# Altium schematic sheet 04_VGA.SchDoc(04_VGA)
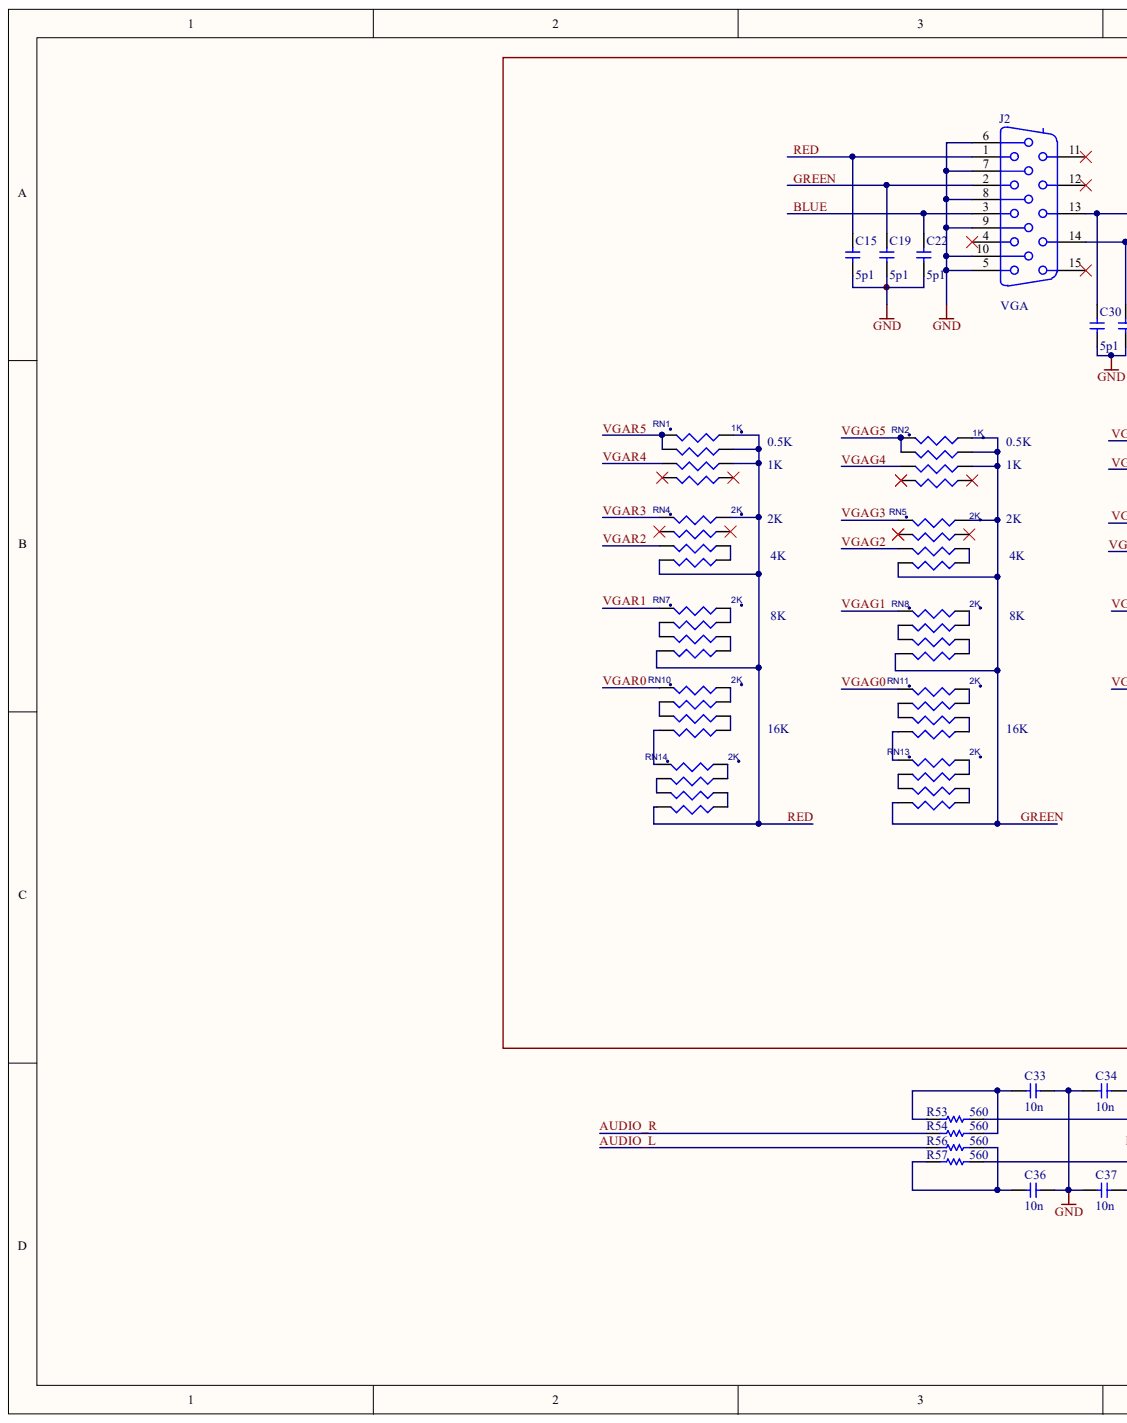
[diagram p0: 04_VGA.SchDoc, left]
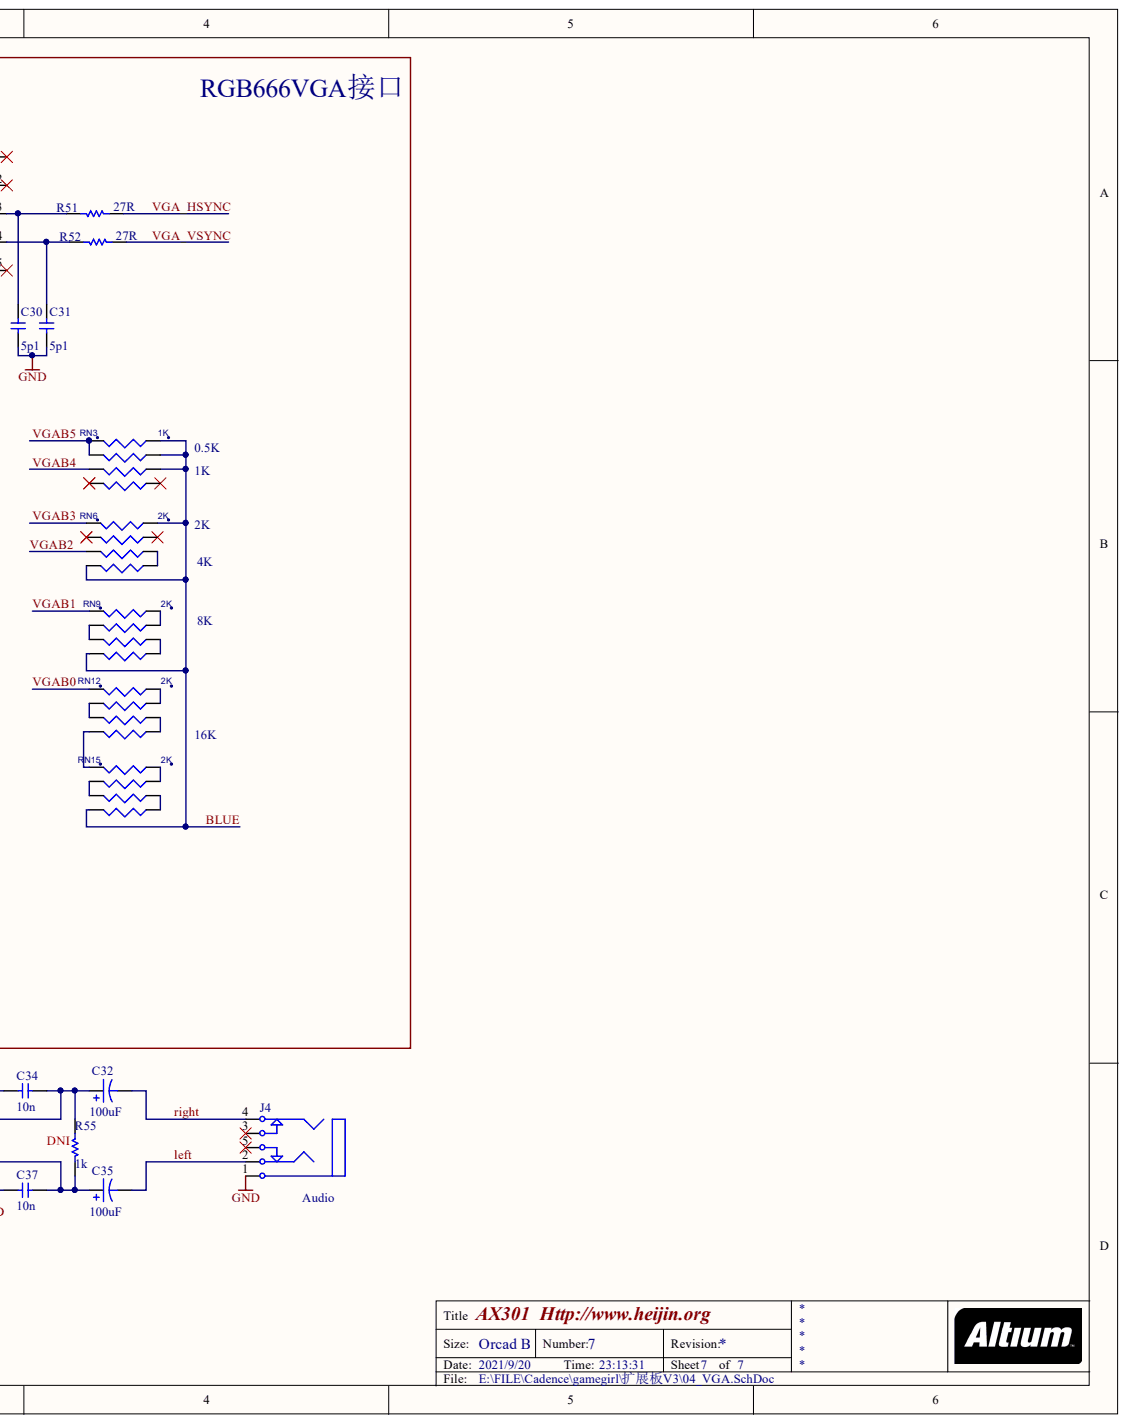
[diagram p1: 04_VGA.SchDoc, right]

## Parts
C15  value 5p1  [0603]  (hidden comment: 0603)
C19  value 5p1  [0603]  (hidden comment: 0603)
C22  value 5p1  [0603]  (hidden comment: 0603)
C30  value 5p1  [0603]  (hidden comment: 0603)
C31  value 5p1  [0603]  (hidden comment: 0603)
C32  value 100uF  [CAPT]  (hidden comment: T520D)
C33  value 10n  [0603]  (hidden comment: 0603)
C34  value 10n  [0603]  (hidden comment: 0603)
C35  value 100uF  [CAPT]  (hidden comment: T520D)
C36  value 10n  [0603]  (hidden comment: 0603)
C37  value 10n  [0603]  (hidden comment: 0603)
J2  VGA  [VGA]
J4  Audio  [phonejack]
R51  value 27R  [0603]  (hidden comment: 0603)
R52  value 27R  [0603]  (hidden comment: 0603)
R53  value 560  [0603]  (hidden comment: 0805)
R54  value 560  [0603]  (hidden comment: 0805)
R55  value 1k  [0603]  (hidden comment: 0603)
R56  value 560  [0603]  (hidden comment: 0805)
R57  value 560  [0603]  (hidden comment: 0805)
RN1  1K  [RN-8P]
RN2  1K  [RN-8P]
RN3  1K  [RN-8P]
RN4  2K  [RN-8P]
RN5  2K  [RN-8P]
RN6  2K  [RN-8P]
RN7  2K  [RN-8P]
RN8  2K  [RN-8P]
RN9  2K  [RN-8P]
RN10  2K  [RN-8P]
RN11  2K  [RN-8P]
RN12  2K  [RN-8P]
RN13  2K  [RN-8P]
RN14  2K  [RN-8P]
RN15  2K  [RN-8P]

## Nets
GND: C15.2 C19.2 C22.2 C30.2 C31.2 C33.1 C34.2 C36.1 C37.2 J2.5 J2.6 J2.7 J2.8 J2.9 J2.10 J4.1
AUDIO_L: R56.1
AUDIO_R: R54.1
BLUE: C22.1 J2.3 RN3.2 RN3.3 RN3.4 RN6.4 RN6.8 RN9.8 RN15.8
GREEN: C19.1 J2.2 RN2.2 RN2.3 RN2.4 RN5.4 RN5.8 RN8.8 RN13.8
LEFT: C35.2 J4.2
RED: C15.1 J2.1 RN1.2 RN1.3 RN1.4 RN4.4 RN4.8 RN7.8 RN14.8
RIGHT: C32.2 J4.4
VGA_HSYNC: R51.1
VGA_VSYNC: R52.1
VGAB0: RN12.5
VGAB1: RN9.5
VGAB2: RN6.7
VGAB3: RN6.5
VGAB4: RN3.7
VGAB5: RN3.5 RN3.6
VGAG0: RN11.5
VGAG1: RN8.5
VGAG2: RN5.7
VGAG3: RN5.5
VGAG4: RN2.7
VGAG5: RN2.5 RN2.6
VGAR0: RN10.5
VGAR1: RN7.5
VGAR2: RN4.7
VGAR3: RN4.5
VGAR4: RN1.7
VGAR5: RN1.5 RN1.6
NetC30_1: C30.1 J2.13 R51.2
NetC31_1: C31.1 J2.14 R52.2
NetC32_1: C32.1 C34.1 R53.2 R55.1
NetC33_2: C33.2 R53.1 R54.2
NetC35_1: C35.1 C37.1 R55.2 R57.2
NetC36_2: C36.2 R56.2 R57.1
NetRN4_1: RN4.1 RN4.2
NetRN5_1: RN5.1 RN5.2
NetRN6_1: RN6.1 RN6.2
NetRN7_1: RN7.1 RN7.2
NetRN7_3: RN7.3 RN7.4
NetRN7_6: RN7.6 RN7.7
NetRN8_1: RN8.1 RN8.2
NetRN8_3: RN8.3 RN8.4
NetRN8_6: RN8.6 RN8.7
NetRN9_1: RN9.1 RN9.2
NetRN9_3: RN9.3 RN9.4
NetRN9_6: RN9.6 RN9.7
NetRN10_1: RN10.1 RN10.2
NetRN10_3: RN10.3 RN10.4
NetRN10_6: RN10.6 RN10.7
NetRN10_8: RN10.8 RN14.5
NetRN11_1: RN11.1 RN11.2
NetRN11_3: RN11.3 RN11.4
NetRN11_6: RN11.6 RN11.7
NetRN11_8: RN11.8 RN13.5
NetRN12_1: RN12.1 RN12.2
NetRN12_3: RN12.3 RN12.4
NetRN12_6: RN12.6 RN12.7
NetRN12_8: RN12.8 RN15.5
NetRN13_1: RN13.1 RN13.2
NetRN13_3: RN13.3 RN13.4
NetRN13_6: RN13.6 RN13.7
NetRN14_1: RN14.1 RN14.2
NetRN14_3: RN14.3 RN14.4
NetRN14_6: RN14.6 RN14.7
NetRN15_1: RN15.1 RN15.2
NetRN15_3: RN15.3 RN15.4
NetRN15_6: RN15.6 RN15.7

Not connected: J2 4 11 12 15; J4 3 5; RN1 1 8; RN2 1 8; RN3 1 8; RN4 3 6; RN5 3 6; RN6 3 6

## Library data of the parts
C15 C19 C22 C30 C31 = CAP_0805: 0805 footprint non-polarised capacitor; Component Kind: Standard; Library Name: Integrated Comp_lib.IntLib
C32 C35 = T520D: Polymer Tantalum Chip Capacitor, T520 Series - KO-CAP Polymer; Code_JEITA: 7343-31; Component Kind: Standard; ComponentLink1Description: Manufacturer Link; ComponentLink1URL: http://www.kemet.com/; ComponentLink2Description: Datasheet; ComponentLink2URL: http://www.kemet.com/kemet/web/homepage/kechome.nsf/vapubfilesname/F3102TA.pdf/$file/F3102TA.pdf; DatasheetDocument: Mar-2001; LatestRevisionDate: 19-Mar-2004; LatestRevisionNote: Silkscreen dimensions re-sized.; Library Name: lib.PcbLib; PackageDocument: Mar-2001; PackageReference: D; Published: 17-Jul-2002; Signal Integrity: T520D; Simulation: CAP; Publisher: Altium Limited
C33 C34 C36 C37 = CAP_0603: 0603 footprint non-polorised capacitor; Component Kind: Standard; Library Name: Integrated Comp_lib.IntLib
J2 = Connector 15: Receptacle Assembly, 15-Pin, Sim Line Connector; Gender: Receptacle Header; PackageDocument: B; Positions: 15; Component Kind: Standard; DatasheetDocument: 24-Nov-1999; LatestRevisionDate: 17-Jul-2002; LatestRevisionNote: Re-released for DXP Platform.; Library Name: Miscellaneous Connectors.IntLib; Orientation: Right Angle; PackageReference: 788574; Published: 15-Jun-2001; Publisher: Altium Limited
J4 = phonejack: Component Kind: Standard; Library Name: lib.SchLib
R51 R52 R55 = RES_0603: 0603 footprint resistor; Component Kind: Standard; Library Name: Integrated Comp_lib.IntLib
R53 R54 R56 R57 = RES_0805: 0805 footprint resistor; Component Kind: Standard; Library Name: Integrated Comp_lib.IntLib
RN1-RN15 = RN_Dup1: Component Kind: Standard
